# Altium schematic sheet GrovePots.SchDoc
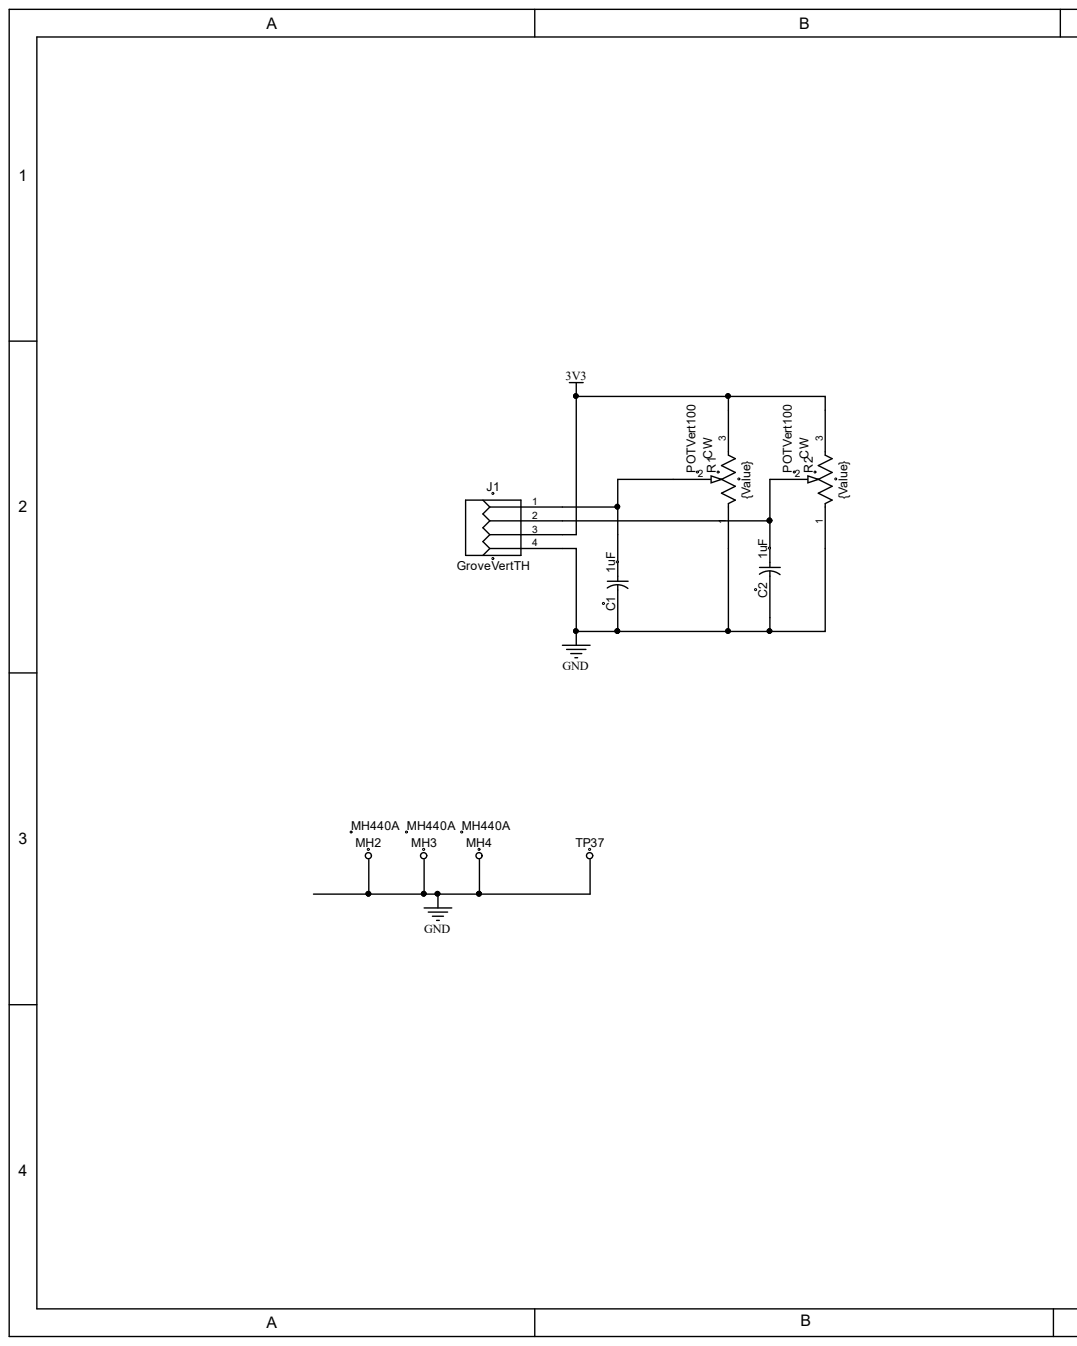
[diagram p0: GrovePots.SchDoc, left]
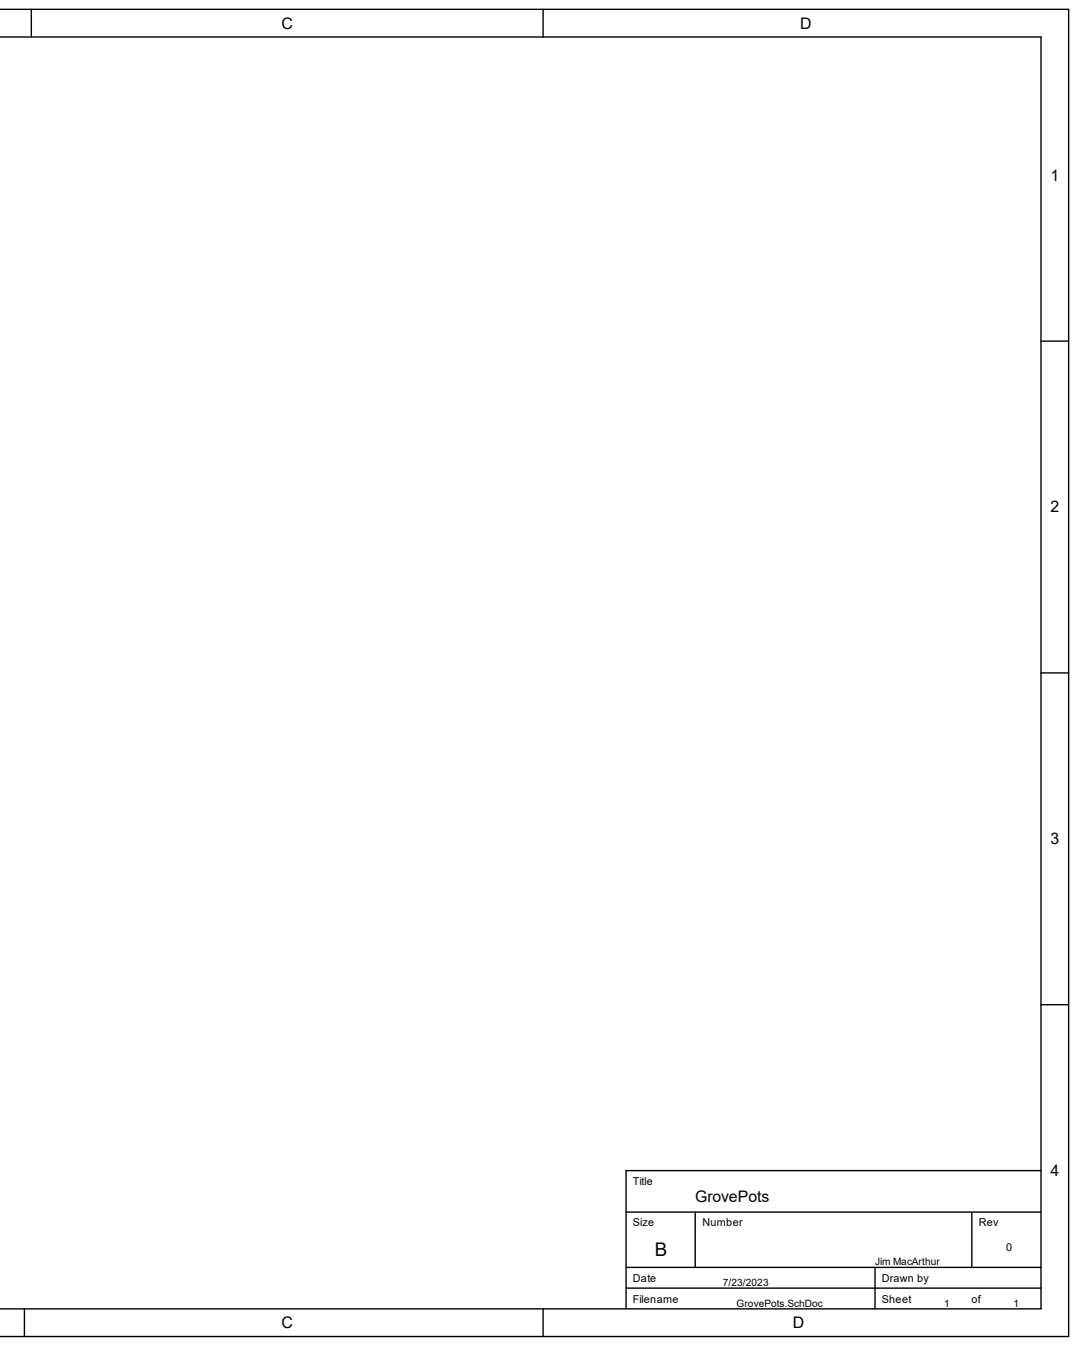
[diagram p1: GrovePots.SchDoc, right]

## Parts
C1  value 1uF  [RC0805_CAP0805(Primary)]  (hidden comment: CAP0805)
C2  value 1uF  [RC0805_CAP0805(Primary)]  (hidden comment: CAP0805)
J1  GroveVertTH  [GroveVertTH]
MH2  MH440A  [MH440A]
MH3  MH440A  [MH440A]
MH4  MH440A  [MH440A]
R1  value {Value}  [POTV100]  (hidden comment: POTVert100)
R2  value {Value}  [POTV100]  (hidden comment: POTVert100)
TP37  TP52  [TP52]  (value not drawn)

## Nets
3V3: J1.3 R1.3 R2.3
GND: C1.B C2.B J1.4 MH2.1 MH3.1 MH4.1 R1.1 R2.1 TP37.1
NetC1_A: C1.A J1.1 R1.2
NetC2_A: C2.A J1.2 R2.2

## Library data of the parts
J1 = GroveVertTH: vertical thru-hole Grove connector; Component Kind: Standard; Library Name: JMAC.IntLib
MH2-MH4 = MH440A: Value: {Value}; Component Kind: Standard; Description: MH440A; Library Name: JMAC.IntLib
R1 R2 = POTVert100: Vertical pot 100 mil pin spacing; Component Kind: Standard; Library Name: JMAC.IntLib
C1 C2 = CAP0805: Component Kind: Standard; Description: CAP0805; Library Name: JMAC.IntLib
TP37 = TP52: Component Kind: Standard; Description: TP52; Library Name: JMAC.IntLib
